# Altium schematic sheet 01.FM175xxDemo.SchDoc
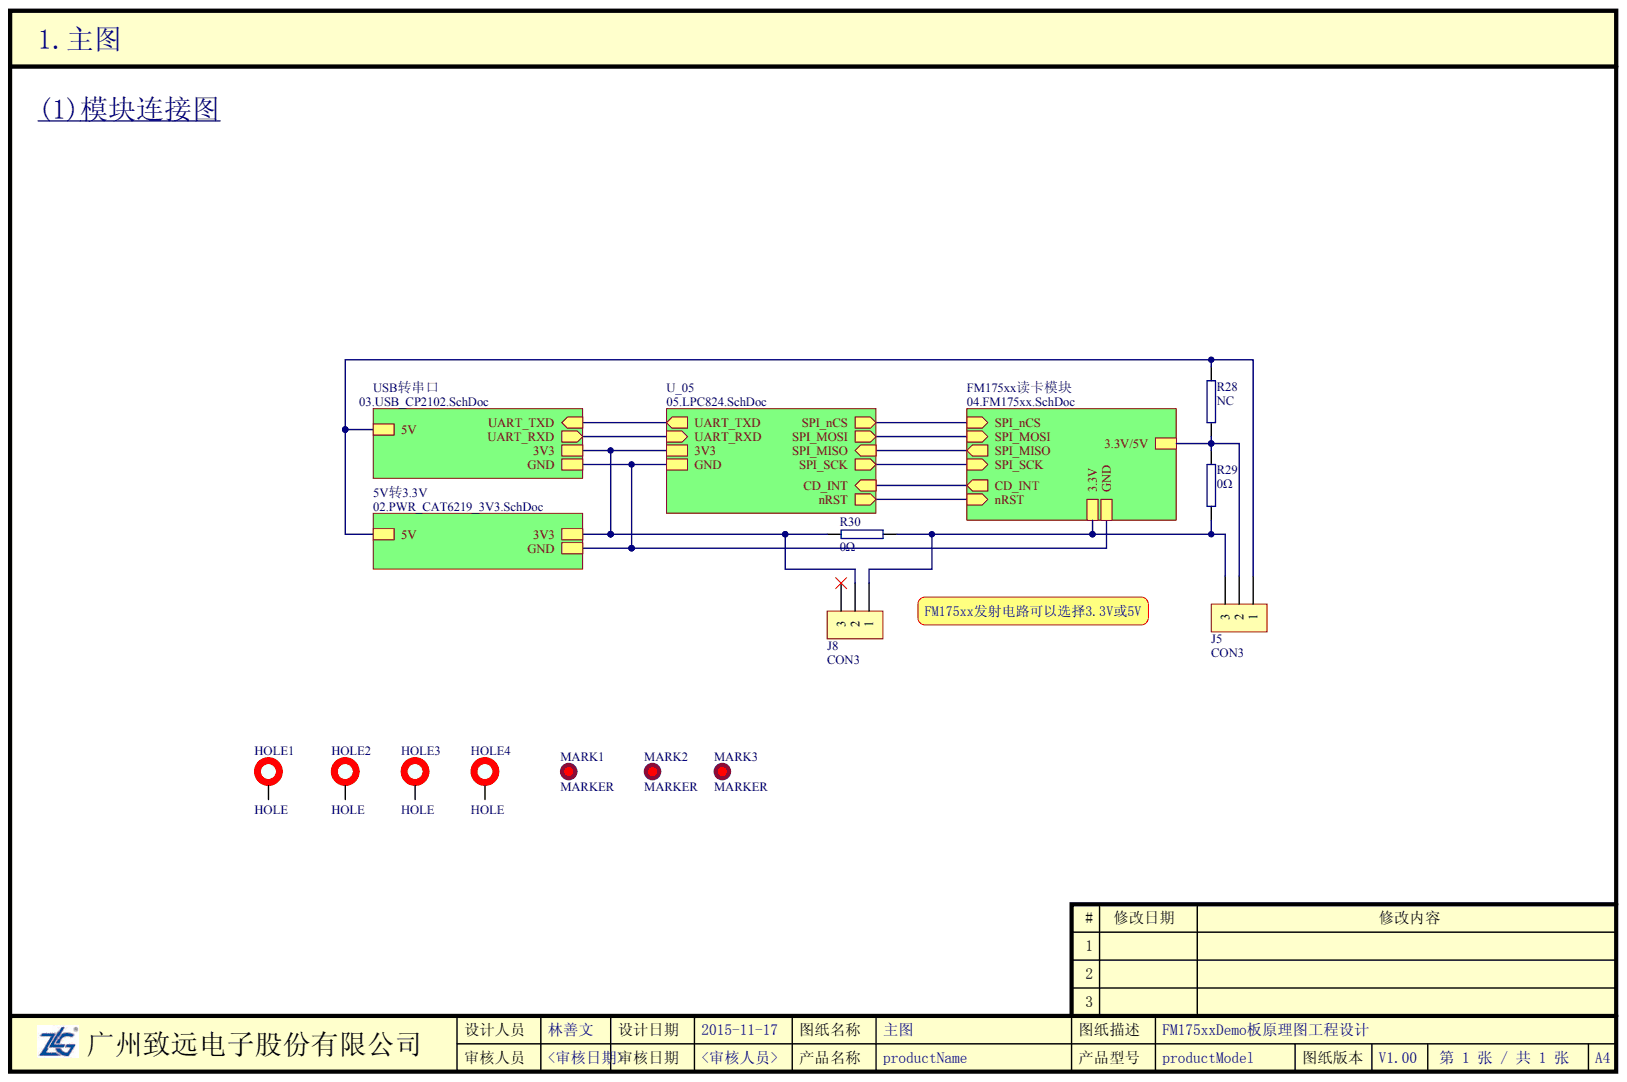
[diagram p0: 01.FM175xxDemo.SchDoc]

## Parts
HOLE1  HOLE
HOLE2  HOLE
HOLE3  HOLE
HOLE4  HOLE
J5  CON3
J8  CON3
MARK1  MARKER
MARK2  MARKER
MARK3  MARKER
R28  NC
R29  0Ω
R30  0Ω

## Nets
NetHOLE1_1: HOLE1.1
NetHOLE2_1: HOLE2.1
NetHOLE3_1: HOLE3.1
NetHOLE4_1: HOLE4.1
NetJ5_1: J5.1 R28.2
NetJ5_2: J5.2 R28.1 R29.2
NetJ5_3: J5.3 J8.1 R29.1 R30.2
NetJ8_2: J8.2 R30.1
NetJ8_3: J8.3
NetMARK1_0: MARK1.0
NetMARK2_0: MARK2.0
NetMARK3_0: MARK3.0
CD_INT:
GND:
NRST:
SPI_MISO:
SPI_MOSI:
SPI_NCS:
SPI_SCK:
UART_RXD:
UART_TXD:

## Library data of the parts
R28 = (no symbol name): 物料代码: 1.01.01.0001; 名称: 电阻; 封装: 0603; 设备封装名称: R0603_0R; 型号: 0Ω; 贴片和直插: SMT; 器件优选方案: 02; Value: NC; RoHS: RoHS; ComponentLink1Description: ErpDataInfo; ComponentLink1URL: http://erp.zlgmcu.com/ErpExt/ErpData/ListMateriel.aspx?pkey=1.01.01.0001
R29 R30 = (no symbol name): 物料代码: 1.01.01.0001; 名称: 电阻; 封装: 0603; 设备封装名称: R0603_0R; 贴片和直插: SMT; 器件优选方案: 02; Value: 0Ω; RoHS: RoHS; 型号: 0Ω; ComponentLink1Description: ErpDataInfo; ComponentLink1URL: http://erp.zlgmcu.com/ErpExt/ErpData/ListMateriel.aspx?pkey=1.01.01.0001
